# Altium schematic sheet Schematic Prints("All Documents",Physical)
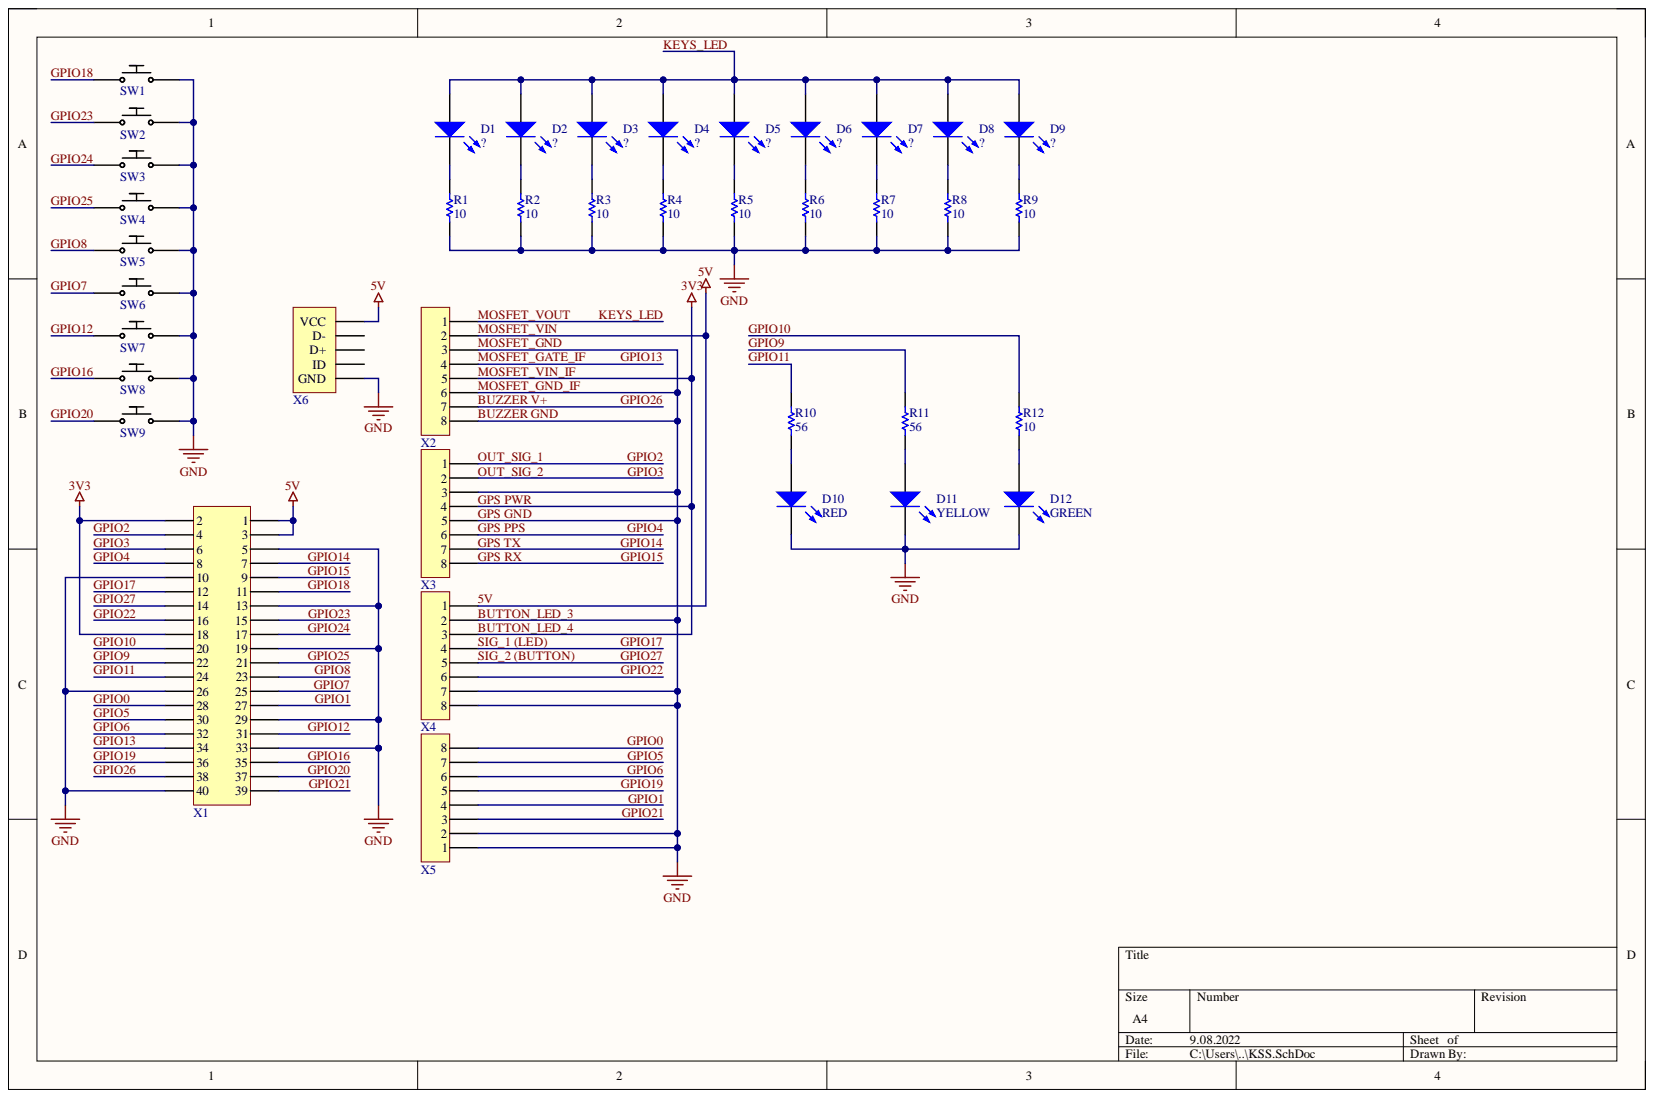
[diagram p0: Schematic Prints("All Documents",Physical)]

## Parts
D1  ?  [LED_1206]
D2  ?  [LED_1206]
D3  ?  [LED_1206]
D4  ?  [LED_1206]
D5  ?  [LED_1206]
D6  ?  [LED_1206]
D7  ?  [LED_1206]
D8  ?  [LED_1206]
D9  ?  [LED_1206]
D10  RED  [LED_1206_DLC]
D11  YELLOW  [LED_1206_DLC]
D12  GREEN  [LED_1206_DLC]
R1  Resistor  [RES 1206]  (value not drawn)
R2  Resistor  [RES 1206]  (value not drawn)
R3  Resistor  [RES 1206]  (value not drawn)
R4  Resistor  [RES 1206]  (value not drawn)
R5  Resistor  [RES 1206]  (value not drawn)
R6  Resistor  [RES 1206]  (value not drawn)
R7  Resistor  [RES 1206]  (value not drawn)
R8  Resistor  [RES 1206]  (value not drawn)
R9  Resistor  [RES 1206]  (value not drawn)
R10  Resistor  [RES 1206]  (value not drawn)
R11  Resistor  [RES 1206]  (value not drawn)
R12  Resistor  [RES 1206]  (value not drawn)
SW1  Pushbutton  [Key switch Outemu]  (value not drawn)
SW2  Pushbutton  [Key switch Outemu]  (value not drawn)
SW3  Pushbutton  [Key switch Outemu]  (value not drawn)
SW4  Pushbutton  [Key switch Outemu]  (value not drawn)
SW5  Pushbutton  [Key switch Outemu]  (value not drawn)
SW6  Pushbutton  [Key switch Outemu]  (value not drawn)
SW7  Pushbutton  [Key switch Outemu]  (value not drawn)
SW8  Pushbutton  [Key switch Outemu]  (value not drawn)
SW9  Pushbutton  [Key switch Outemu]  (value not drawn)
X1  GoldPin_F_20x2  [GOLDPIN_F_20x2]  (value not drawn)
X2  ?  [SCREW_TERMINAL_X8 5,08mm]  (value not drawn)
X3  ?  [SCREW_TERMINAL_X8 5,08mm]  (value not drawn)
X4  ?  [SCREW_TERMINAL_X8 5,08mm]  (value not drawn)
X5  ?  [SCREW_TERMINAL_X8 5,08mm]  (value not drawn)
X6  USB_MICRO_B  [USB_MICRO_B_MX-105017-0001]  (value not drawn)

## Nets
5V: X1.1 X1.3 X2.2 X4.1 X6.1(VCC)
BUTTON_LED_3: D10.2 D11.2 D12.2 R1.1 R2.1 R3.1 R4.1 R5.1 R6.1 R7.1 R8.1 R9.1 SW1.2 SW2.2 SW3.2 SW4.2 SW5.2 SW6.2 SW7.2 SW8.2 SW9.2 X1.5 X1.10 X1.13 X1.19 X1.26 X1.29 X1.33 X1.40 X2.3 X2.6 X2.8 X3.3 X3.5 X4.2 X4.7 X4.8 X5.1 X5.2 X6.5(GND)
BUTTON_LED_4: X1.2 X1.18 X2.5 X3.4 X4.3
BUZZER V+: X1.38 X2.7
GPIO0: X1.28 X5.8
GPIO1: X1.27 X5.4
GPIO2: X1.4 X3.1
GPIO3: X1.6 X3.2
GPIO4: X1.8 X3.6
GPIO5: X1.30 X5.7
GPIO6: X1.32 X5.6
GPIO7: SW6.1 X1.25
GPIO8: SW5.1 X1.23
GPIO9: R11.2 X1.22
GPIO10: R12.2 X1.20
GPIO11: R10.2 X1.24
GPIO12: SW7.1 X1.31
GPIO13: X1.34 X2.4
GPIO14: X1.7 X3.7
GPIO15: X1.9 X3.8
GPIO16: SW8.1 X1.35
GPIO17: X1.12 X4.4
GPIO18: SW1.1 X1.11
GPIO19: X1.36 X5.5
GPIO20: SW9.1 X1.37
GPIO21: X1.39 X5.3
GPIO22: X1.16 X4.6
GPIO23: SW2.1 X1.15
GPIO24: SW3.1 X1.17
GPIO25: SW4.1 X1.21
GPIO27: X1.14 X4.5
KEYS_LED: D1.1 D2.1 D3.1 D4.1 D5.1 D6.1 D7.1 D8.1 D9.1 X2.1
NetD1_2: D1.2 R1.2
NetD2_2: D2.2 R2.2
NetD3_2: D3.2 R3.2
NetD4_2: D4.2 R4.2
NetD5_2: D5.2 R5.2
NetD6_2: D6.2 R6.2
NetD7_2: D7.2 R7.2
NetD8_2: D8.2 R8.2
NetD9_2: D9.2 R9.2
NetD10_1: D10.1 R10.1
NetD11_1: D11.1 R11.1
NetD12_1: D12.1 R12.1
NetX6_2: X6.2(D-)
NetX6_3: X6.3(D+)
NetX6_4: X6.4(ID)

## Library data of the parts
D1-D12 = Diode LED: Component Kind: Standard; Library Name: COMPONENTS_2022.IntLib
R1-R9 R12 = Resistor: Resistance: 10; Component Kind: Standard; Library Name: COMPONENTS_2022.IntLib
R10 R11 = Resistor: Resistance: 56; Component Kind: Standard; Library Name: COMPONENTS_2022.IntLib
X2-X5 = Connector x8: Component Kind: Standard; Library Name: COMPONENTS_2022.IntLib
SW1-SW9 = Pushbutton: Component Kind: Standard; Library Name: COMPONENTS_2022.IntLib
X1 = GoldPin_F_20x2: Component Kind: Standard; Library Name: COMPONENTS_2022.IntLib
X6 = USB_MICRO_B: Component Kind: Standard; Library Name: COMPONENTS_2022.IntLib
